# Altium schematic sheet [05] - POWER SUPPLY.SchDoc("[05] - POWER SUPPLY")
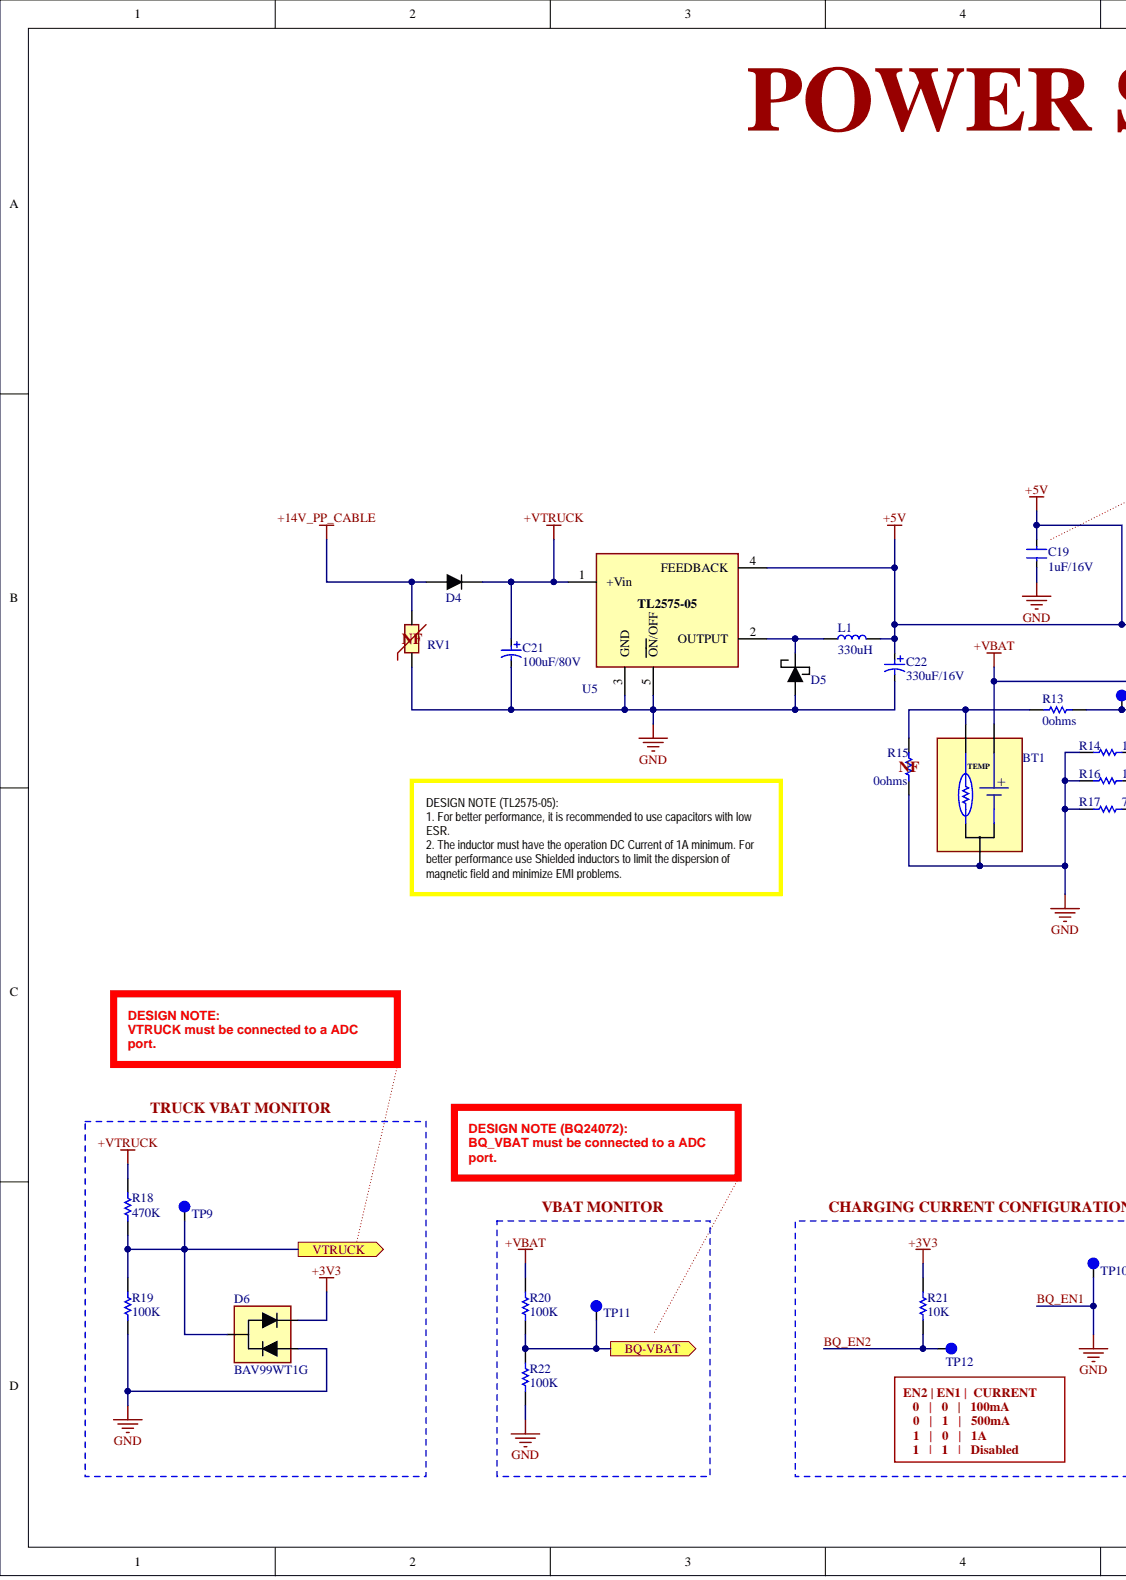
[diagram p0: [05] - POWER SUPPLY.SchDoc, left]
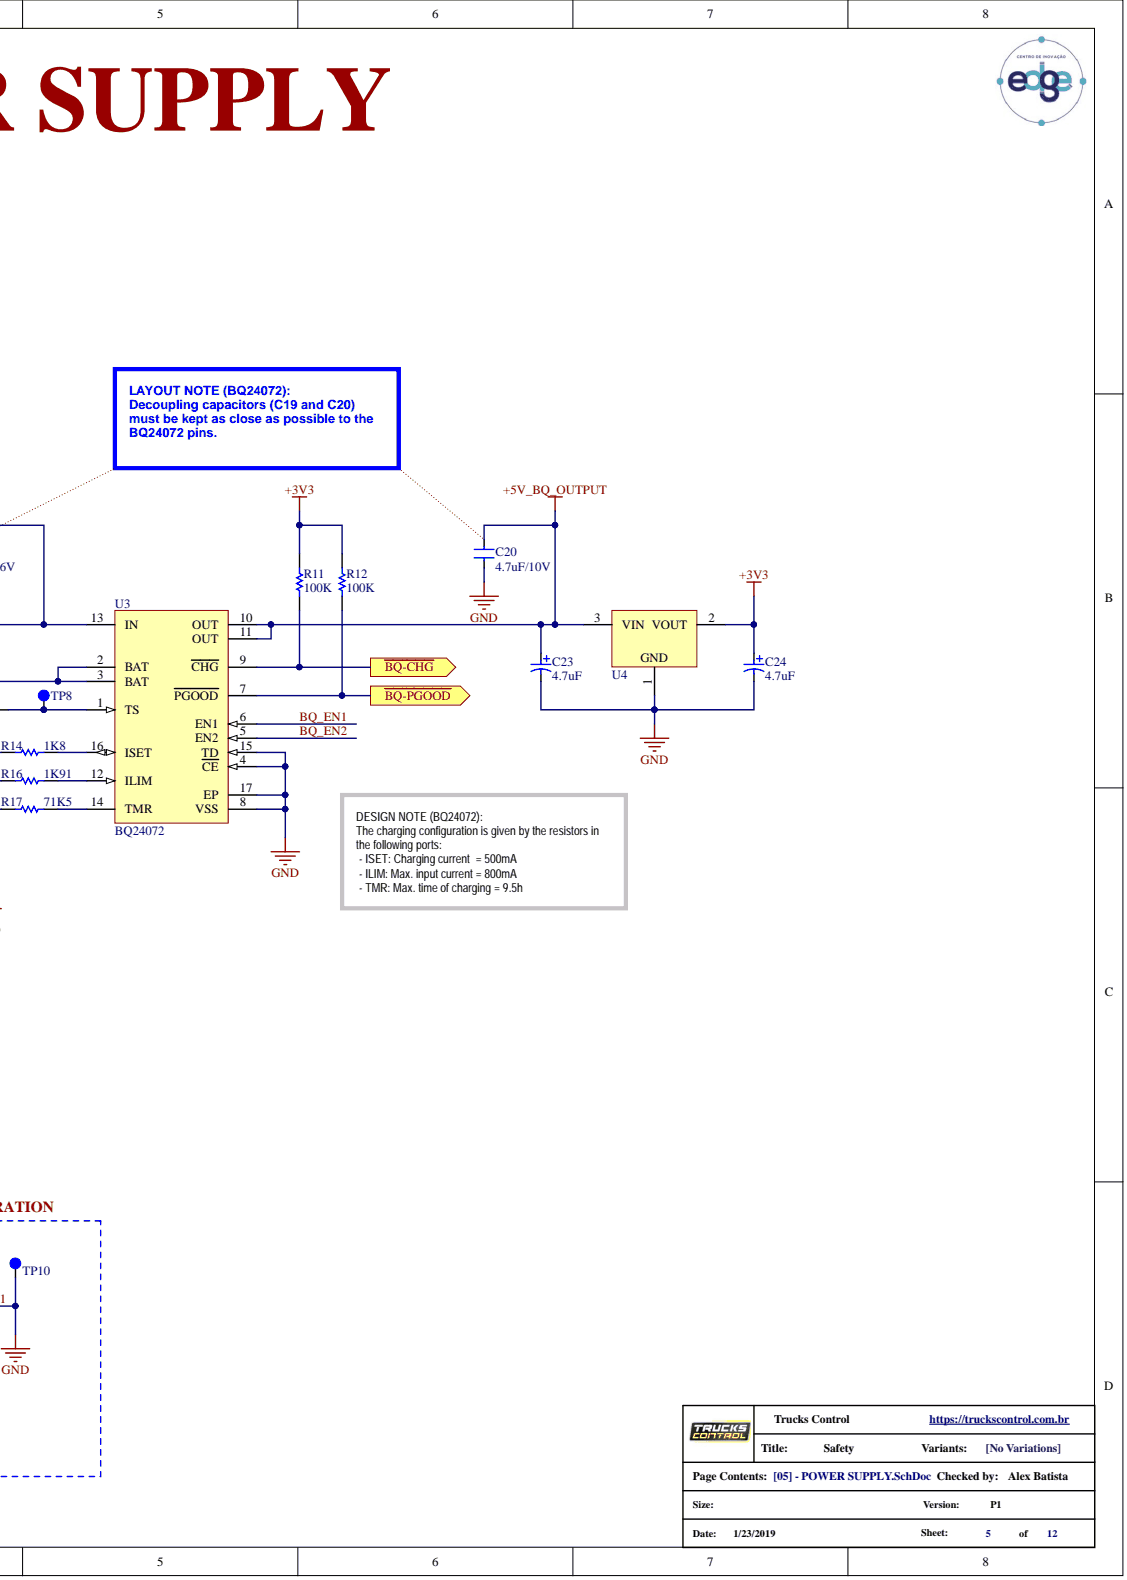
[diagram p1: [05] - POWER SUPPLY.SchDoc, right]

## Parts
BT1  Battery Connector  [B3B-PH-SM4-TBT(LF)(SN)]  (value not drawn)
C19  1uF/16V  [C0402]
C20  4.7uF/10V  [C0402]
C21  100uF/80V  [EEV-FK1K101Q]
C22  330uF/16V  [EEE-1CA331UP]
C23  4.7uF  [Tantalum Capacitor Case A]
C24  4.7uF  [Tantalum Capacitor Case A]
D4  600V/1A  [DO-214BA]  (value not drawn)
D5  SS16T3G  [SS16T3G]  (value not drawn)
D6  BAV99WT1G  [SOT-323]
L1  330uH  [SRR1280A-331K]
R11  100K  [R0402]
R12  100K  [R0402]
R13  0ohms  [R0402]
R14  1K8  [R0402]
R15  0ohms  [R0402]
R16  1K91  [R0402]
R17  71K5  [R0402]
R18  470K  [R0402]
R19  100K  [R0402]
R20  100K  [R0402]
R21  10K  [R0402]
R22  100K  [R0402]
RV1  V39ZA20P  [V39ZA20P]  (value not drawn)
TP8  TestPoint  [Test Point]  (value not drawn)  (no BOM)
TP9  TestPoint  [Test Point]  (value not drawn)  (no BOM)
TP10  TestPoint  [Test Point]  (value not drawn)  (no BOM)
TP11  TestPoint  [Test Point]  (value not drawn)  (no BOM)
TP12  TestPoint  [Test Point]  (value not drawn)  (no BOM)
U3  BQ24072  [VQFN-16]
U4  AP2114H-3.3TRG1  [AP2114H-3.3TRG1]  (value not drawn)
U5  TL2575HV  [DPAK170P1524X483-6N]  (value not drawn)

## Ports
BQ-VBAT, VTRUCK, ~{BQ-CHG}, ~{BQ-PGOOD}

## Nets
+3V3: C24.1 D6.2 R11.2 R12.2 R21.2 U4.2
+5V: C19.2 C22.1 L1.2 U3.13(IN) U5.4(FEEDBACK)
+5V_BQ_OUTPUT: C20.2 C23.1 U3.10(OUT) U3.11(OUT) U4.3
+14V_PP_CABLE: D4.1 RV1.1
+VBAT: BT1.1 R20.2 U3.2(BAT) U3.3(BAT)
+VTRUCK: C21.1 D4.2 R18.2 U5.1(+Vin)
~{BQ-CHG}: R11.1 U3.9(CHG) ; port ~{BQ-CHG}
~{BQ-PGOOD}: R12.1 U3.7(PGOOD) ; port ~{BQ-PGOOD}
BQ-VBAT: R20.1 R22.2 TP11.1 ; port BQ-VBAT
BQ_EN2: R21.1 TP12.1 U3.5(EN2)
GND: BT1.3 C19.1 C20.1 C21.2 C22.2 C23.2 C24.2 D5.2 D6.1 R14.1 R15.1 R16.1 R17.1 R19.1 R22.1 RV1.2 TP10.1 U3.4(CE) U3.6(EN1) U3.8(VSS) U3.15(TD) U3.17(EP) U4.1 U5.3(GND) U5.5(ON/OFF)
VTRUCK: D6.3 R18.1 R19.2 TP9.1 ; port VTRUCK
NetBT1_2: BT1.2 R13.1 R15.2
NetD5_1: D5.1 L1.1 U5.2(OUTPUT)
NetR13_2: R13.2 TP8.1 U3.1(TS)
NetR14_2: R14.2 U3.16(ISET)
NetR16_2: R16.2 U3.12(ILIM)
NetR17_2: R17.2 U3.14(TMR)

## Library data of the parts
BT1 = BATTERY_PACK: Manufacturer: JST Sales America Inc.; Supplier Part Number 1: 455-2948-1-ND; Supplier 1: Digikey; Manufacturer Part Number: B3B-PH-SM4-TBT(LF)(SN); Description: Battery Connector; Component Kind: Standard; Library Name: tc-pcb-safety_V1I1.SchLib
C19 = CL05A105MO5NNNC: 1µF ±20% 16V X5R 0402 (1005 Metric)Ceramic Capacitor -55°C ~ 85°C Surface Mount, MLCC; Manufacturer: Samsung; Capacitance: 1uF; Width: 0.02inch; Voltage Rating (DC): 16V; Voltage Rating: 16V; Tolerance: 20%; Thickness: 0.022inch; Supplier Part Number 1: 1276-1447-1-ND; Supplier 1: Digi-Key; Stock 1: 100000; Pricing 1: 1=0.1, 10=0.056, 100=0.0248, 500=0.01772, 1000=0.01392, 2500=0.01266, 5000=0.01164 (USD); Packaging: Tape and Reel; Package Quantity: 10000; Mount: Surface Mount; Min Operating Temperature: -55°C; Max Operating Temperature: 85°C; Manufacturer Part Number: CL05A105MO5NNNC; Length: 0.039inch; Component Kind: Standard; Case Code (Metric): 1005; Case Code (Imperial): 0402; Case/Package: 0402; Library Name: tc-pcb-safety_V1I1.SchLib
C20 = GRM155R61A475MEAAD: 4.7µF 10V Ceramic Capacitor X5R 0402 (1005 Metric); Manufacturer: Murata; Supplier Part Number 1: 81-GRM155R61A475MEAD; Supplier 1: Mouser; Stock 1: 121376; Pricing 1: 1=0.25, 10=0.169, 50=0.169, 100=0.086, 1000=0.052, 10000=0.035 (USD); Manufacturer Part Number: GRM155R61A475MEAAD; Component Kind: Standard; Case/Package: 0402; Library Name: tc-pcb-safety_V1I1.SchLib
C21 = EEV-FK1K101Q: Cap Aluminum Lytic 100uF 80V 20% (12.5 X 13.5mm) SMD 0.32 Ohm 500mA 2000h 105C T/R; Manufacturer: Panasonic; Life (Hours): 5000Hour; Leakage Current: 3uA; Impedance: 320mR; Dielectric Material: Aluminium; Width: 900um; Voltage Rating (DC): 80V; Voltage Rating: 80V; Voltage: 80V; Tolerance: 20%; Termination: SMD/SMT; Supplier Part Number 1: 667-EEV-FK1K101Q; Supplier 1: Mouser; Stock 1: 5685; Ripple Current (AC): 500mA; Ripple Current: 500mA; REACH SVHC: No SVHC; Radiation Hardening: No; Pricing 1: 1=1.24, 10=0.846, 50=0.846, 100=0.651, 1000=0.488, 10000=0.415 (USD); Packaging: Tape and Reel; Package Quantity: 1; Number of Pins: 2; Mount: PCB,Surface Mount; Min Operating Temperature: -55°C; Max Operating Temperature: 105°C; Manufacturer Part Number: EEV-FK1K101Q; Length: 14mm; Lead Free: Lead Free; Height - Seated (Max): 0.531inch; Height: 13.5mm; ESR (Equivalent Series Resistance): 320mR; Diameter: 12.5mm; Contact Plating: Tin; ComponentLink6URL: https://www.alliedelec.com/m/d/e9dd47fa10a053d1f2828ce8bc944f54.pdf; ComponentLink6Description: https://www.alliedelec.com/m/d/e9dd47fa10a053d1f2828ce8bc944f54.pdf; ComponentLink5URL: http://www.farnell.com/datasheets/1676921.pdf; ComponentLink5Description: http://www.farnell.com/datasheets/1676921.pdf; ComponentLink4URL: http://www.farnell.com/datasheets/2245246.pdf; ComponentLink4Description: http://www.farnell.com/datasheets/2245246.pdf; ComponentLink3URL: http://uk.rs-online.com/webdocs/0e4e/0900766b80e4e8b0.pdf; ComponentLink3Description: http://uk.rs-online.com/webdocs/0e4e/0900766b80e4e8b0.pdf; ComponentLink2URL: http://www.farnell.com/datasheets/2002520.pdf; ComponentLink2Description: http://www.farnell.com/datasheets/2002520.pdf; ComponentLink1URL: http://industrial.panasonic.com/www-data/pdf/ABA0000/ABA0000CE120.pdf; ComponentLink1Description: http://industrial.panasonic.com/www-data/pdf/ABA0000/ABA0000CE120.pdf; Component Kind: Standard; Case/Package: Radial; Capacitance: 100uF; Library Name: tc-pcb-safety_V1I1.SchLib
C22 = EEE-1CA331UP: 330uF 16V 20% Cap Aluminum Lytic  (8 X 10.2mm) SMD 320mA 2000h 85C T/R; Manufacturer: Panasonic; Life (Hours): 2000Hour; Leakage Current: 52.8uA; Dielectric Material: Aluminium; Voltage Rating (DC): 16V; Voltage Rating: 16V; Voltage: 16V; Tolerance: 20%; Termination: SMD/SMT; Supplier Part Number 1: 667-EEE-1CA331UP; Supplier 1: Mouser; Stock 1: 10846; Ripple Current (AC): 320mA; Ripple Current: 320mA; REACH SVHC: No SVHC; Radiation Hardening: No; Pricing 1: 1=0.65, 10=0.374, 50=0.374, 100=0.252, 1000=0.158, 10000=0.128 (USD); Packaging: Tape and Reel; Package Quantity: 1; Mount: PCB,Surface Mount; Min Operating Temperature: -40°C; Max Operating Temperature: 85°C; Manufacturer Part Number: EEE-1CA331UP; Length: 10.5mm; Lead Free: Lead Free; Height - Seated (Max): 0.402inch; Height: 10.2mm; Diameter: 8mm; Contact Plating: Tin; ComponentLink5URL: https://www.verical.com/server-webapp/html/getDatasheet?partID=169248; ComponentLink5Description: https://www.verical.com/server-webapp/html/getDatasheet?partID=169248; ComponentLink4URL: http://www.farnell.com/datasheets/1909355.pdf; ComponentLink4Description: http://www.farnell.com/datasheets/1909355.pdf; ComponentLink3URL: http://industrial.panasonic.com/www-data/pdf/ABA0000/ABA0000CE2.pdf; ComponentLink3Description: http://industrial.panasonic.com/www-data/pdf/ABA0000/ABA0000CE2.pdf; ComponentLink2URL: https://www.alliedelec.com/m/d/310b2cfd0553a89b5ffae819c6e32d0a.pdf; ComponentLink2Description: https://www.alliedelec.com/m/d/310b2cfd0553a89b5ffae819c6e32d0a.pdf; ComponentLink1URL: http://www.industrial.panasonic.com/www-data/pdf/ABA0000/ABA0000CE2.pdf; ComponentLink1Description: http://www.industrial.panasonic.com/www-data/pdf/ABA0000/ABA0000CE2.pdf; Component Kind: Standard; Case/Package: Radial; Capacitance: 330uF; Library Name: tc-pcb-safety_V1I1.SchLib
C23 C24 = T491A475K016AT: CAP TANT 4.7UF 16V 10% 1206; Manufacturer: KEMET; Ripple Current: 137mA; Polarity: Polar; Life (Hours): 2000Hour; Leakage Current: 800nA; ESR (Equivalent Series Resistance): 4R; Dissipation Factor: 6%; Dielectric Material: Tantalum; Width: 1.6mm; Voltage Rating (DC): 16V; Voltage Rating: 16V; Voltage: 16V; Tolerance: 10%; Termination: SMD/SMT; Supplier Part Number 2: 80-T491A475K107280; Supplier Part Number 1: 80-T491A475K016; Supplier 2: Mouser; Supplier 1: Mouser; Stock 1: 28062; REACH SVHC: No SVHC; Radiation Hardening: No; Pricing 1: 1=0.33, 10=0.225, 50=0.157, 100=0.135, 1000=0.085, 10000=0.076 (USD); Packaging: Tape and Reel; Package Quantity: 1; Number of Pins: 2; Mount: PCB,Surface Mount; Min Operating Temperature: -55°C; Max Operating Temperature: 125°C; Manufacturer Part Number: T491A475K016AT; Length: 3.2mm; Lead Free: Lead Free; Height - Seated (Max): 0.071inch; Height: 1.6mm; Features: General Purpose; Depth: 1.6mm; Component Kind: Standard; Case Code (Metric): 3216; Case Code (Imperial): 1206; Case/Package: 1206; Capacitance: 4.7uF; Library Name: tc-pcb-safety_V1I1.SchLib
D4 = RGF1J-E3/67A: DIODE GEN PURP 600V 1A DO214BA; Manufacturer: Vishay Semiconductors; Reverse Recovery Time: 250ns; Peak Reverse Current: 5uA; Peak Non-Repetitive Surge Current: 30A; Forward Voltage: 1.3V; Element Configuration: Single; Supplier Part Number 1: 625-RGF1J-E3; Supplier 1: Mouser; Stock 1: 0; Recovery Time: 250ns; REACH SVHC: Unknown; Radiation Hardening: No; Packaging: Tape and Reel; Package Quantity: 1; Number of Pins: 2; Mount: Surface Mount; Min Operating Temperature: -65°C; Max Surge Current: 30A; Max Reverse Voltage (DC): 600V; Max Repetitive Reverse Voltage (Vrrm): 600V; Max Operating Temperature: 175°C; Max Forward Surge Current (Ifsm): 30A; Manufacturer Part Number: RGF1J-E3/67A; Lead Free: Lead Free; Forward Current: 1A; ComponentLink2URL: http://components.arrow.com/part/dataview/42816194S9420904N5680; ComponentLink2Description: http://components.arrow.com/part/dataview/42816194S9420904N5680; ComponentLink1URL: http://www.vishay.com/docs/88697/rgf1.pdf; ComponentLink1Description: http://www.vishay.com/docs/88697/rgf1.pdf; Component Kind: Standard; Average Rectified Current: 1A; Library Name: tc-pcb-safety_V1I1.SchLib
D5 = SS16T3G: DIODE SCHOTTKY 60V 1A SMA; Manufacturer: ON Semiconductor; Polarity: Standard; Peak Reverse Current: 200uA; Peak Non-Repetitive Surge Current: 40A; Forward Voltage: 510mV; Element Configuration: Single; Width: 2.92mm; Voltage Rating (DC): 60V; Supplier Part Number 1: 863-SS16T3G; Supplier 1: Mouser; Stock 1: 256502; REACH SVHC: No SVHC; Radiation Hardening: No; Pricing 1: 1=0.47, 10=0.301, 50=0.301, 100=0.129, 1000=0.099, 10000=0.067 (USD); Packaging: Tape and Reel; Package Quantity: 1; Output Current: 1A; Number of Pins: 2; Min Operating Temperature: -55°C; Max Surge Current: 40A; Max Reverse Voltage (DC): 60V; Max Reverse Leakage Current: 200uA; Max Repetitive Reverse Voltage (Vrrm): 60V; Max Operating Temperature: 150°C; Max Forward Surge Current (Ifsm): 40A; Manufacturer Part Number: SS16T3G; Length: 4.57mm; Lead Free: Lead Free; Height: 2.05mm; Forward Current: 1A; Current Rating: 1A; ComponentLink3URL: http://uk.rs-online.com/webdocs/1221/0900766b81221312.pdf; ComponentLink3Description: http://uk.rs-online.com/webdocs/1221/0900766b81221312.pdf; ComponentLink2URL: http://www.mouser.com/ds/2/308/SS16-D-92003.pdf; ComponentLink2Description: http://www.mouser.com/ds/2/308/SS16-D-92003.pdf; ComponentLink1URL: http://www.onsemi.com/pub/Collateral/SS16-D.PDF; ComponentLink1Description: http://www.onsemi.com/pub/Collateral/SS16-D.PDF; Component Kind: Standard; Case/Package: SMA; Average Rectified Current: 1A; Library Name: tc-pcb-safety_V1I1.SchLib
D6 = BAV99WT1G: DIODE ARRAY GP 70V 200MA SOT323; Manufacturer: ON Semiconductor / Fairchild; Reverse Recovery Time: 6ns; Power Dissipation: 300mW; Peak Reverse Current: 2.5uA; Peak Non-Repetitive Surge Current: 2A; Max Reverse Leakage Current: 2.4uA; Forward Voltage: 1V; Element Configuration: Common Cathode; Width: 1.35mm; Weight: 0.03g; Termination: SMD/SMT; Supplier Part Number 1: 863-BAV99WT1G; Supplier 1: Mouser; Stock 1: 22267; Reverse Voltage: 70V; Recovery Time: 6ns; REACH SVHC: No SVHC; Radiation Hardening: No; Pricing 1: 1=0.15, 10=0.13, 50=0.13, 100=0.046, 1000=0.031, 10000=0.021 (USD); Packaging: Tape and Reel; Package Quantity: 1; Number of Pins: 3; Mount: Surface Mount; Min Operating Temperature: -65°C; Max Surge Current: 2A; Max Reverse Voltage (DC): 70V; Max Repetitive Reverse Voltage (Vrrm): 70V; Max Operating Temperature: 150°C; Max Forward Surge Current (Ifsm): 2A; Manufacturer Part Number: BAV99WT1G; Length: 2.2mm; Lead Free: Lead Free; Height: 0.9mm; Forward Current: 200mA; Contact Plating: Matte,Tin; Component Kind: Standard; Case/Package: SOT-323; Average Rectified Current: 200mA; Library Name: tc-pcb-safety_V1I1.SchLib
L1 = SRR1280A-331K: Inductor Power Shielded Wirewound 330uH 10% 1KHz 18Q-Factor Ferrite 1.1A 600mOhm DCR Automotive T/R; Manufacturer: Bourns; Self Resonant Frequency: 2.9MHz; Saturation Current: 1.4A; DC Resistance (DCR): 600mR; Width: 0.492inch; Tolerance: 10%; Test Frequency: 1kHz; Supplier Part Number 1: 652-SRR1280A-331K; Supplier 1: Mouser; Stock 1: 3945; Shielding: Shielded; RMS Current (Irms): 1.1A; REACH SVHC: No SVHC; Ratings: AEC-Q200; Pricing 1: 1=1.13, 10=0.967, 50=0.806, 100=0.758, 1000=0.478, 10000=0.439 (USD); Packaging: Cut Tape; Package Quantity: 400; Mount: Surface Mount; Min Operating Temperature: -40°C; Military Standard: Not; Max Operating Temperature: 125°C; Max DC Current: 1.1A; Manufacturer Part Number: SRR1280A-331K; Length: 0.492inch; Inductance Tolerance: 10%; Inductance: 330uh; Height - Seated (Max): 0.315inch; Height: 7.5mm; Depth: 12.8mm; Current Rating: 1.1A; Core Material: Ferrite; Component Kind: Standard; Case/Package: Nonstandard; Library Name: tc-pcb-safety_V1I1.SchLib
R11 R12 R19 R20 R22 = RC0402FR-07100KL: 100K OHM 1% 1/16W 0402 RES SMD; Manufacturer: Yageo; Working Voltage: 50V; Temperature Coefficient: 100ppm/°C; Resistance: 100kR; Power Rating: 63mW; Width: 0.02inch; Voltage Rating: 50V; Tolerance: 1%; Termination: SMD/SMT; Supplier Part Number 1: 603-RC0402FR-07100KL; Supplier 1: Mouser; Stock 1: 3740589; REACH SVHC: No SVHC; Pricing 1: 1=0.1, 10=0.012, 50=0.012, 100=0.004, 1000=0.003, 10000=0.003 (USD); Packaging: Tape and Reel; Package Quantity: 1; Number of Terminations: 2; Min Operating Temperature: -55°C; Military Standard: Not; Max Power Dissipation: 63mW; Max Operating Temperature: 155°C; Manufacturer Part Number: RC0402FR-07100KL; Length: 0.039inch; Lead Free: Lead Free; Height: 0.016inch; Features: Moisture Resistant; Depth: 0.5mm; Composition: Thick Film; Component Kind: Standard; Case Code (Metric): 1005; Case Code (Imperial): 0402; Case/Package: 0402; Library Name: tc-pcb-safety_V1I1.SchLib
R13 R15 = CRG0402ZR: 0 ohm, 50 V, 0402 [1005 Metric], 63 mW, CRG Series; Manufacturer: TE Connectivity; Voltage Rating (DC): 50V; Tolerance: 0%; Temperature Coefficient: 200ppm/°C; Resistance: 0mR; Power Rating: 63mW; Number of Resistors: 1; Insulation Resistance: 1GR; Width: 0.5mm; Weight: 2.3E-05oz; Voltage Rating: 50V; Termination: Solder; Supplier Part Number 1: 279-CRG0402ZR; Supplier 1: Mouser; Stock 1: 540000; REACH SVHC: Unknown; Radiation Hardening: No; Pricing 1: 10000=0.002 (USD); Packaging: Tape and Reel; Package Quantity: 10000; Number of Terminations: 2; Number of Pins: 2; Mount: Surface Mount; Min Operating Temperature: -55°C; Max Operating Temperature: 125°C; Manufacturer Part Number: CRG0402ZR; Length: 1mm; Height: 0.35mm; ELV: Compliant; Depth: 0.5mm; Composition: Thick Film; Component Kind: Standard; Case Code (Metric): 1005; Case Code (Imperial): 0402; Case/Package: 0402; Library Name: tc-pcb-safety_V1I1.SchLib
R14 = ERJ-2RKF1801X: 1K8  0.1W, 1%,  0402, RESISTOR; Manufacturer: Panasonic; Voltage Rating (DC): 50V; Temperature Coefficient: 100ppm/°C; Resistance: 1.8kR; Power Rating: 100mW; Width: 0.02inch; Voltage Rating: 50V; Tolerance: 1%; Termination: SMD/SMT; Supplier Part Number 1: 667-ERJ-2RKF1801X; Supplier 1: Mouser; Stock 1: 85886; REACH SVHC: No SVHC; Radiation Hardening: No; Pricing 1: 1=0.18, 10=0.026, 50=0.026, 100=0.015, 1000=0.009, 10000=0.006 (USD); Packaging: Tape and Reel; Package Quantity: 1; Number of Terminations: 2; Number of Pins: 2; Mount: Surface Mount; Min Operating Temperature: -55°C; Military Standard: Not; Max Power Dissipation: 100mW; Max Operating Temperature: 155°C; Manufacturer Part Number: ERJ-2RKF1801X; Length: 1mm; Lead Free: Lead Free; Height: 0.35mm; Features: Automotive AEC-Q200; Depth: 0.5mm; Contact Plating: Tin; Composition: Thick Film; Component Kind: Standard; Case Code (Metric): 1005; Case Code (Imperial): 0402; Case/Package: 0402; Library Name: tc-pcb-safety_V1I1.SchLib
R16 = RC0402FR-071K91L: 1K91 OHM 1% Thick Film Resistors - SMD; Manufacturer: Yageo; Voltage Rating (DC): 50V; Temperature Coefficient: 100ppm/°C; Resistance: 1.91kR; Power Rating: 62.5mW; Max Power Dissipation: 63mW; Width: 0.02inch; Voltage Rating: 50V; Tolerance: 1%; Termination: SMD/SMT; Supplier Part Number 1: 603-RC0402FR-071K91L; Supplier 1: Mouser; Stock 1: 0; Pricing 1: 1=0.1, 10=0.012, 50=0.012, 100=0.004, 1000=0.003, 10000=0.003 (USD); Packaging: Tape and Reel; Number of Terminations: 2; Min Operating Temperature: -55°C; Military Standard: Not; Max Operating Temperature: 155°C; Manufacturer Part Number: RC0402FR-071K91L; Length: 1mm; Lead Free: Lead Free; Height: 0.016inch; Features: Moisture Resistant; Depth: 0.5mm; Contact Plating: Matte,Tin; Composition: Thick Film; Component Kind: Standard; Case Code (Metric): 1005; Case Code (Imperial): 0402; Case/Package: 0402; Library Name: tc-pcb-safety_V1I1.SchLib
R17 = RC0402FR-0771K5L: 71K5 OHM 1% Thick Film Resistors - SMD; Manufacturer: Yageo; Voltage Rating (DC): 50V; Temperature Coefficient: 100ppm/°C; Resistance: 71.5kR; Power Rating: 62.5mW; Max Power Dissipation: 63mW; Width: 0.02inch; Voltage Rating: 50V; Tolerance: 1%; Termination: SMD/SMT; Supplier Part Number 1: 603-RC0402FR-0771K5L; Supplier 1: Mouser; Stock 1: 52573; Pricing 1: 1=0.1, 10=0.012, 50=0.012, 100=0.004, 1000=0.003, 10000=0.003 (USD); Packaging: Tape and Reel; Number of Terminations: 2; Min Operating Temperature: -55°C; Military Standard: Not; Max Operating Temperature: 155°C; Manufacturer Part Number: RC0402FR-0771K5L; Length: 0.039inch; Lead Free: Lead Free; Height: 0.016inch; Features: Moisture Resistant; Depth: 0.5mm; Composition: Thick Film; Component Kind: Standard; Case Code (Metric): 1005; Case Code (Imperial): 0402; Case/Package: 0402; Library Name: tc-pcb-safety_V1I1.SchLib
R18 = RC0402FR-07470KL: 470K OHM 1% 1/16W 0402 RES SMD; Manufacturer: Yageo; Voltage Rating (DC): 50V; Temperature Coefficient: 100ppm/°C; Resistance: 470kR; Power Rating: 62.5mW; Max Power Dissipation: 63mW; Width: 0.02inch; Voltage Rating: 50V; Tolerance: 1%; Termination: SMD/SMT; Supplier Part Number 1: 603-RC0402FR-07470KL; Supplier 1: Mouser; Stock 1: 373636; REACH SVHC: No SVHC; Pricing 1: 1=0.1, 10=0.012, 50=0.012, 100=0.004, 1000=0.003, 10000=0.003 (USD); Packaging: Tape and Reel; Package Quantity: 1; Number of Terminations: 2; Number of Pins: 2; Min Operating Temperature: -55°C; Military Standard: Not; Max Operating Temperature: 155°C; Manufacturer Part Number: RC0402FR-07470KL; Length: 1mm; Lead Free: Lead Free; Height: 0.016inch; Features: Moisture Resistant; Depth: 0.5mm; Composition: Thick Film; Component Kind: Standard; Case Code (Metric): 1005; Case Code (Imperial): 0402; Case/Package: 0402; Library Name: tc-pcb-safety_V1I1.SchLib
R21 = RC0402JR-7D10KL: 10K OHM 5% 1/16W 0402; Manufacturer: Yageo; Supplier Part Number 1: 603-RC0402JR-7D10KL; Supplier 1: Mouser; Stock 1: 178452; Pricing 1: 1=0.1, 10=0.01, 50=0.01, 100=0.004, 1000=0.003, 10000=0.002 (USD); Manufacturer Part Number: RC0402JR-7D10KL; Component Kind: Standard; Library Name: tc-pcb-safety_V1I1.SchLib
RV1 = V39ZA20P: VARISTOR 39V 2KA DISC 20MM; Manufacturer: Littelfuse; Voltage Rating (DC): 31V; Voltage Rating (AC): 25V; Varistor Voltage: 43V; Number of Circuits: 1; Min Varistor Voltage: 35.1V; Max Varistor Voltage: 42.9V; Lead/Base Style: Radial; Energy: 20J; Clamping Voltage: 76V; Clamping Current: 20A; Capacitance: 12nF; Voltage Rating: 31V; Termination: Radial; Supplier Part Number 1: 576-V39ZA20P; Supplier 1: Mouser; Stock 1: 1965; REACH SVHC: No SVHC; Pricing 1: 1=0.96, 10=0.738, 50=0.738, 100=0.56, 1000=0.381, 10000=0.31 (USD); Packaging: Bulk; Package Quantity: 500; Mount: Radial,Through Hole; Min Operating Temperature: -55°C; Max Voltage Rating (DC): 31V; Max Voltage Rating (AC): 25V; Max Surge Current: 2kA; Max Output Current: 2kA; Max Operating Temperature: 85°C; Manufacturer Part Number: V39ZA20P; Lead Pitch: 8.5mm; Lead Free: Lead Free; Diameter: 20mm; Depth: 5mm; Current Rating: 2kA; Component Kind: Standard; Case/Package: Radial; Library Name: tc-pcb-safety_V1I1.SchLib
TP8-TP12 = Test_Point: Library Name: tc-pcb-safety_V1I1.SchLib
U3 = BQ24072RGT: USB-Friendly Li-Ion Battery Charger and Power-Path Management IC, Vout 200mV above Vbat | Linear; Manufacturer Part Number 1: BQ24072RGTR; Manufacturer 1: Texas Instruments; Supplier Part Number 1: 595-BQ24072RGTR; Supplier 1: Mouser; Library Name: tc-pcb-tracker.SchLib; Component Kind: Standard
U4 = AP2114H-3.3TRG1: IC REG LDO 3.3V 1A SOT223; Manufacturer: Diodes; Quiescent Current: 65uA; Power Supply Rejection Ratio (PSRR): 65dB; Polarity: Positive; Output Type: Fixed; Number of Regulators: 1; Min Input Voltage: 2.5V; Max Input Voltage: 6V; Dropout Voltage: 450mV; Accuracy: 1.5%; Width: 3.7mm; Weight: 0.000282oz; Supplier Part Number 1: 621-AP2114H-3.3TRG1; Supplier 1: Mouser; Stock 1: 19269; Pricing 1: 1=0.36, 10=0.268, 50=0.268, 100=0.146, 1000=0.109, 10000=0.088 (USD); Packaging: Tape and Reel; Package Quantity: 4000; Output Voltage: 3.3V; Output Current: 1A; Number of Pins: 3; Number of Outputs: 1; Mount: Surface Mount; Min Operating Temperature: -40°C; Max Output Current: 1A; Max Operating Temperature: 85°C; Manufacturer Part Number: AP2114H-3.3TRG1; Length: 6.7mm; Lead Free: Lead Free; Height: 1.7mm; Component Kind: Standard; Case/Package: SOT-223; Library Name: tc-pcb-safety_V1I1.SchLib
U5 = TL2575HV-05IKTTR: IC REG BUCK 5V 1A 5-DDPAK; Manufacturer: Texas Instruments; Synchronous Rectifier: No; Switching Frequency: 58kHz; Quiescent Current: 5mA; Output Type: Adjustable,Fixed; Operating Supply Voltage: 60V; Number of Outputs: 1; Min Input Voltage: 4.75V; Efficiency: 77%; Width: 9.65mm; Weight: 0.056128oz; Supplier Part Number 1: 595-TL2575HV-05IKTTR; Supplier 1: Mouser; Stock 1: 1572; REACH SVHC: No SVHC; Radiation Hardening: No; Pricing 1: 1=3.16, 10=2.84, 50=2.84, 100=2.33, 1000=1.67, 10000=1.53 (USD); Packaging: Tape and Reel; Package Quantity: 1; Output Voltage: 5V; Output Current: 1A; Number of Pins: 6; Nominal Output Voltage: 5V; Min Output Voltage: 5V; Min Operating Temperature: -40°C; Max Output Voltage: 5V; Max Output Current: 1A; Max Operating Temperature: 125°C; Max Input Voltage: 60V; Manufacturer Part Number: TL2575HV-05IKTTR; Length: 10.67mm; Lead Free: Lead Free; Height: 4.83mm; Component Kind: Standard; Case/Package: TO-263-5; Library Name: tc-pcb-safety_V1I1.SchLib
